# Altium schematic sheet AUX.SchDoc(AUX)
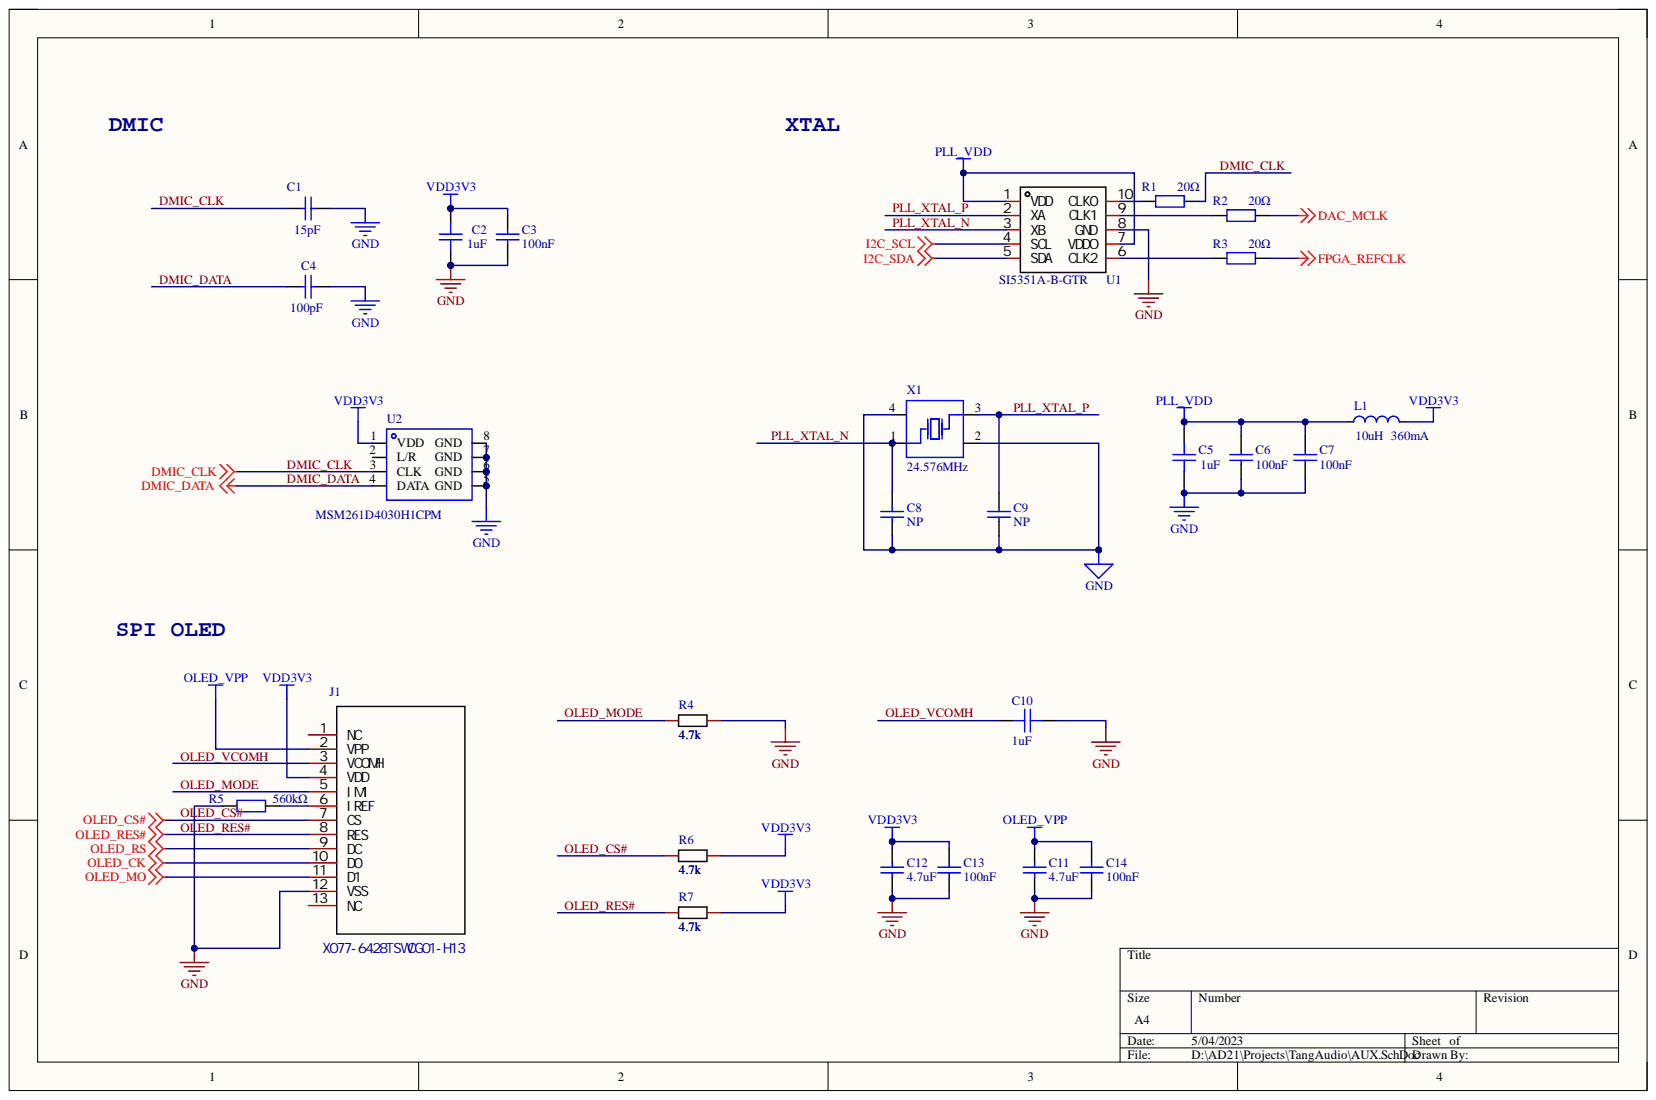
[diagram p0: AUX.SchDoc]

## Parts
C1  value 15pF  [C0402]
C2  value 1uF  [C0603]
C3  value 100nF  [C0402]
C4  value 100pF  [C0402]
C5  value 1uF  [C0603]
C6  value 100nF  [C0402]
C7  value 100nF  [C0402]
C8  value NP  [C0402]
C9  value NP  [C0402]
C10  value 1uF  [C0603]
C11  value 4.7uF  [C0603]
C12  value 4.7uF  [C0603]
C13  value 100nF  [C0402]
C14  value 100nF  [C0402]
J1  X077-6428TSWCG01-H13  [OLED]
L1  value 10uH  Rated Current: 360mA  [L0805]
R1  value 20Ω  [R0402]
R2  value 20Ω  [R0402]
R3  value 20Ω  [R0402]
R4  4.7k  [R0402]
R5  value 560kΩ  [R0402]
R6  4.7k  [R0402]
R7  4.7k  [R0402]
U1  SI5351A-B-GTR  [MSOP-10_L3.0-W3.0-P0.50-LS5.0-BL]
U2  (no value)  [MIC-SMD_8P-L3.0-W4.0-P0.85-BL]
X1  value 24.576MHz  [OSC-SMD_4P-L3.2-W2.5-BL]

## Nets
DAC_MCLK: R2.2
FPGA_REFCLK: R3.2
GND: C1.2 C2.1 C3.1 C4.2 C5.1 C6.1 C7.1 C8.2 C9.2 C10.1 C11.2 C12.2 C13.1 C14.1 J1.12(VSS) R4.2 R5.1 U1.8(GND) U2.5(GND) U2.6(GND) U2.7(GND) U2.8(GND) X1.2 X1.4
I2C_SCL: U1.4(SCL)
I2C_SDA: U1.5(SDA)
OLED_CK: J1.10(D0)
OLED_MO: J1.11(D1)
OLED_RS: J1.9(DC)
OLED_VPP: C11.1 C14.2 J1.2(VPP)
PLL_VDD: C5.2 C6.2 C7.2 L1.1 U1.1(VDD) U1.7(VDDO)
VDD3V3: C2.2 C3.2 C12.1 C13.2 J1.4(VDD) L1.2 R6.2 R7.2 U2.1(VDD)
DMIC_CLK: C1.1 R1.2 U2.3(CLK)
DMIC_DATA: C4.1 U2.4(DATA)
OLED_CS#: J1.7(CS) R6.1
OLED_MODE: J1.5(IM1) R4.1
OLED_RES#: J1.8(RES) R7.1
OLED_VCOMH: C10.2 J1.3(VCOMH)
PLL_XTAL_N: C8.1 U1.3(XB) X1.1
PLL_XTAL_P: C9.1 U1.2(XA) X1.3
NetJ1_6: J1.6(IREF) R5.2
NetR1_1: R1.1 U1.10(CLK0)
NetR2_1: R2.1 U1.9(CLK1)
NetR3_1: R3.1 U1.6(CLK2)

Not connected: J1 1(NC) 13(NC); U2 2(L/R)

## Library data of the parts
C1 C8 C9 = 0402CG150J500NT: Manufacturer: FH(风华); Add into BOM: yes; Temperature Coefficient: C0G; Tolerance: ±5%; Voltage Rated: 50V; Component Kind: Standard; Convert to PCB: yes; Datasheet: https://atta.szlcsc.com/upload/public/pdf/source/20170923/C1548_1506149266133988355.pdf; Device: 0402CG150J500NT; JLCPCB Part Class: Basic Part; LCSC Part Name: 15pF ±5% 50V; Manufacturer Part: 0402CG150J500NT; PCB3D: C0402_L1.0-W0.5-H0.5; Supplier: LCSC; Supplier Footprint: 0402; Supplier Part: C1548; Symbol: 0402CG150J500NT
C2 C5 C10 = CL05A105KA5NQNC: Manufacturer: SAMSUNG(三星); Add into BOM: yes; Temperature Coefficient: X5R; Tolerance: ±10%; Voltage Rated: 25V; Component Kind: Standard; Convert to PCB: yes; Datasheet: https://atta.szlcsc.com/upload/public/pdf/source/20160103/1457707735223.pdf; Device: CL05A105KA5NQNC; JLCPCB Part Class: Basic Part; LCSC Part Name: 1uF ±10% 25V; Manufacturer Part: CL05A105KA5NQNC; PCB3D: C0402_L1.0-W0.5-H0.5; Supplier: LCSC; Supplier Footprint: 0402; Supplier Part: C52923; Symbol: CL05A105KA5NQNC
C3 C6 C7 C13 C14 = CL05B104KO5NNNC: Manufacturer: SAMSUNG(三星); Add into BOM: yes; Temperature Coefficient: X7R; Tolerance: ±10%; Voltage Rated: 16V; Component Kind: Standard; Convert to PCB: yes; Datasheet: https://atta.szlcsc.com/upload/public/pdf/source/20160103/1457707674891.pdf; Device: CL05B104KO5NNNC; JLCPCB Part Class: Basic Part; LCSC Part Name: 100nF ±10% 16V; Manufacturer Part: CL05B104KO5NNNC; PCB3D: C0402_L1.0-W0.5-H0.5; Supplier: LCSC; Supplier Footprint: 0402; Supplier Part: C1525; Symbol: CL05B104KO5NNNC
C4 = 0402CG101J500NT: Manufacturer: FH(风华); Add into BOM: yes; Temperature Coefficient: C0G; Tolerance: ±5%; Voltage Rated: 50V; Component Kind: Standard; Convert to PCB: yes; Datasheet: https://atta.szlcsc.com/upload/public/pdf/source/20170923/C1546_1506149265265988353.pdf; Device: 0402CG101J500NT; JLCPCB Part Class: Basic Part; LCSC Part Name: 100pF ±5% 50V; Manufacturer Part: 0402CG101J500NT; PCB3D: C0402_L1.0-W0.5-H0.5; Supplier: LCSC; Supplier Footprint: 0402; Supplier Part: C1546; Symbol: 0402CG101J500NT
C11 C12 = CL10A475KO8NNNC: Manufacturer: SAMSUNG(三星); Add into BOM: yes; Temperature Coefficient: X5R; Tolerance: ±10%; Voltage Rated: 16V; Component Kind: Standard; Convert to PCB: yes; Datasheet: https://atta.szlcsc.com/upload/public/pdf/source/20160103/1457707703134.pdf; Device: CL10A475KO8NNNC; JLCPCB Part Class: Basic Part; LCSC Part Name: 4.7uF ±10% 16V; Manufacturer Part: CL10A475KO8NNNC; PCB3D: C0603_L1.6-W0.8-H0.8; Supplier: LCSC; Supplier Footprint: 0603; Supplier Part: C19666; Symbol: CL10A475KO8NNNC
L1 = SLM2012100MIT: Manufacturer: Sunltech(韩国顺磁); Add into BOM: yes; DC Resistance (DCR): 550mΩ; Tolerance: ±20%; Component Kind: Standard; Convert to PCB: yes; Datasheet: https://atta.szlcsc.com/upload/public/pdf/source/20180528/C216156_746607D01E5EB4C50E0D2BE40685D2FE.pdf; Device: SLM2012100MIT; JLCPCB Part Class: Extended Part; LCSC Part Name: 10uH ±20% 360mA 550mΩ; Manufacturer Part: SLM2012100MIT; PCB3D: R0805_L2.0-W1.3-H0.6; Ratings: -; Saturation Current (Isat): -; Supplier: LCSC; Supplier Footprint: 0805; Supplier Part: C2831365; Symbol: SLM2012100MIT
R1-R3 R5 = 0603WAF200JT5E: Manufacturer: UNI-ROYAL(厚声); Add into BOM: yes; Overload Voltage (Max): 75V; Power(Watts): 1/10W; Temperature Coefficient: ±100ppm/; Tolerance: ±1%; Component Kind: Standard; Convert to PCB: yes; Datasheet: https://atta.szlcsc.com/upload/public/pdf/source/20200306/C422600_1E6D84923E4A46A82E41ADD87F860B5C.pdf; Device: 0603WAF200JT5E; JLCPCB Part Class: Basic Part; LCSC Part Name: 20Ω ±1%; Manufacturer Part: 0603WAF200JT5E; Operating Temperature Range: -55~+155; PCB3D: R0603_L1.6-W0.8-H0.8; Supplier: LCSC; Supplier Footprint: 0603; Supplier Part: C22950; Symbol: 0603WAF200JT5E; Type: 厚膜电阻
R4 R6 R7 = Res_0603: Add into BOM: yes; Symbol: 电阻; Component Kind: Standard; Convert to PCB: yes; Device: Res_0603; Name: 4.7k; PCB3D: R0603_L1.6-W0.8-H0.5; Supplier Footprint: 0603; Value: 4.7k
U1 = SI5351A-B-GTR: Manufacturer: SILICON LABS(芯科); Add into BOM: yes; Component Kind: Standard; Convert to PCB: yes; Datasheet: https://atta.szlcsc.com/upload/public/pdf/source/20201217/C504891_43D9F8D26F790B9DA481E85A362B0988.pdf; Device: SI5351A-B-GTR; JLCPCB Part Class: Extended Part; LCSC Part Name: SI5351A-B-GTR; Manufacturer Part: SI5351A-B-GTR; Name: SI5351A-B-GTR; PCB3D: MSOP-10_L3.0-W3.0-H1.1-LS5.0-P0.50; Supplier: LCSC; Supplier Footprint: MSOP-10(3x3x0.5); Supplier Part: C504891; Symbol: SI5351A-B-GTR
U2 = MSM261D4030H1CPM: Manufacturer: MEMS(敏芯微); Add into BOM: yes; Component Kind: Standard; Convert to PCB: yes; Datasheet: https://atta.szlcsc.com/upload/public/pdf/source/20201204/C966942_B60AB8B480FB10DBF6E1564FEF312E24.pdf; Device: MSM261D4030H1CPM; JLCPCB Part Class: Extended Part; LCSC Part Name: MSM261D4030H1CPM; Manufacturer Part: MSM261D4030H1CPM; PCB3D: MIC-SMD_8P-L3.0-W4.0-P0.85-BL; Supplier: LCSC; Supplier Footprint: SMD,4x3x1mm; Supplier Part: C966942; Symbol: MSM261D4030H1CPM
X1 = X322525MOB4SI: Manufacturer: YXC扬兴科技; Add into BOM: yes; Crystal Type: 贴片无源晶振; Frequency Stability: ±30ppm; Frequency Tolerance: ±10ppm; Load Capacitance: 12pF; Component Kind: Standard; Convert to PCB: yes; Datasheet: https://atta.szlcsc.com/upload/public/pdf/source/20210329/C112971_1F611BDC486E193C1706F6D8EB5AA189.pdf; Device: X322525MOB4SI; JLCPCB Part Class: Basic Part; LCSC Part Name: 25MHz ±10ppm 12pF; Manufacturer Part: X322525MOB4SI; Operating Temperature: -40~+85; PCB3D: OSC-SMD_4P-L3.2-W2.5-H1.0; Supplier: LCSC; Supplier Footprint: SMD3225-4P; Supplier Part: C9006; Symbol: X322525MOB4SI
J1 = X077-6428TSWCG01-H13: Component Kind: Standard; Device: X077-6428TSWCG01-H13; Name: X077-6428TSWCG01-H13; Symbol: X077-6428TSWCG01-H13
